# Altium schematic sheet FPGA_PWR.SchDoc
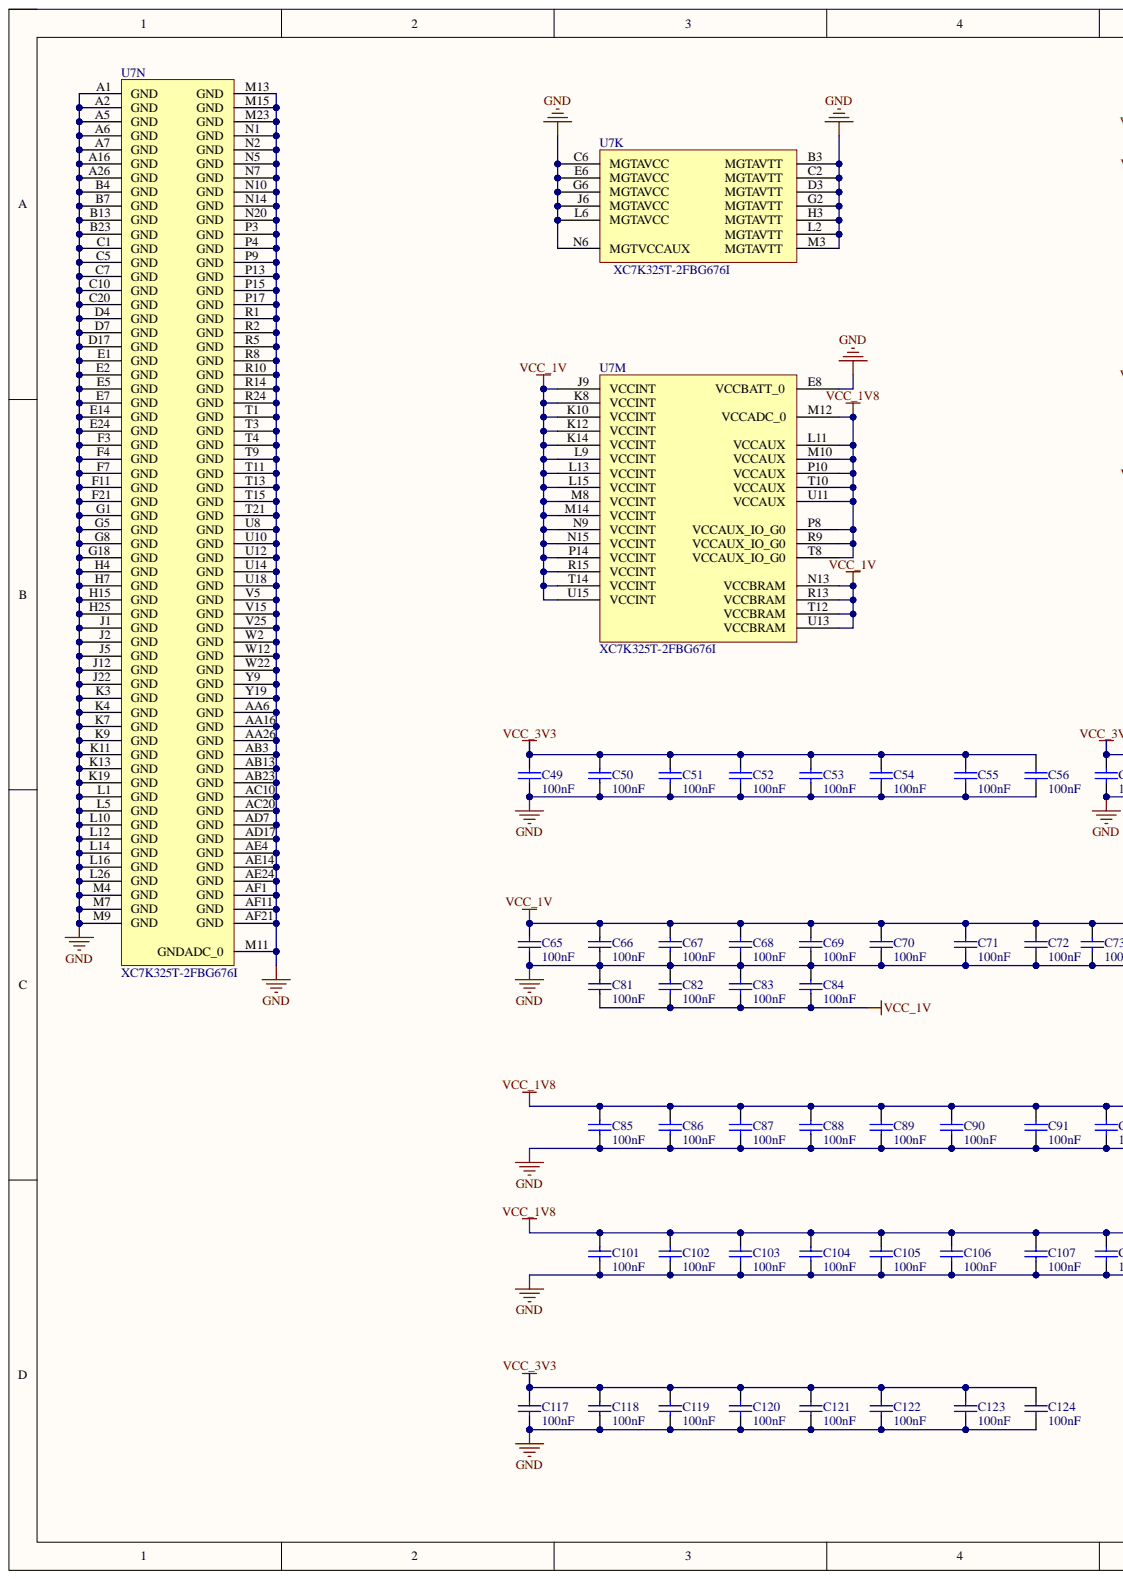
[diagram p0: FPGA_PWR.SchDoc, left]
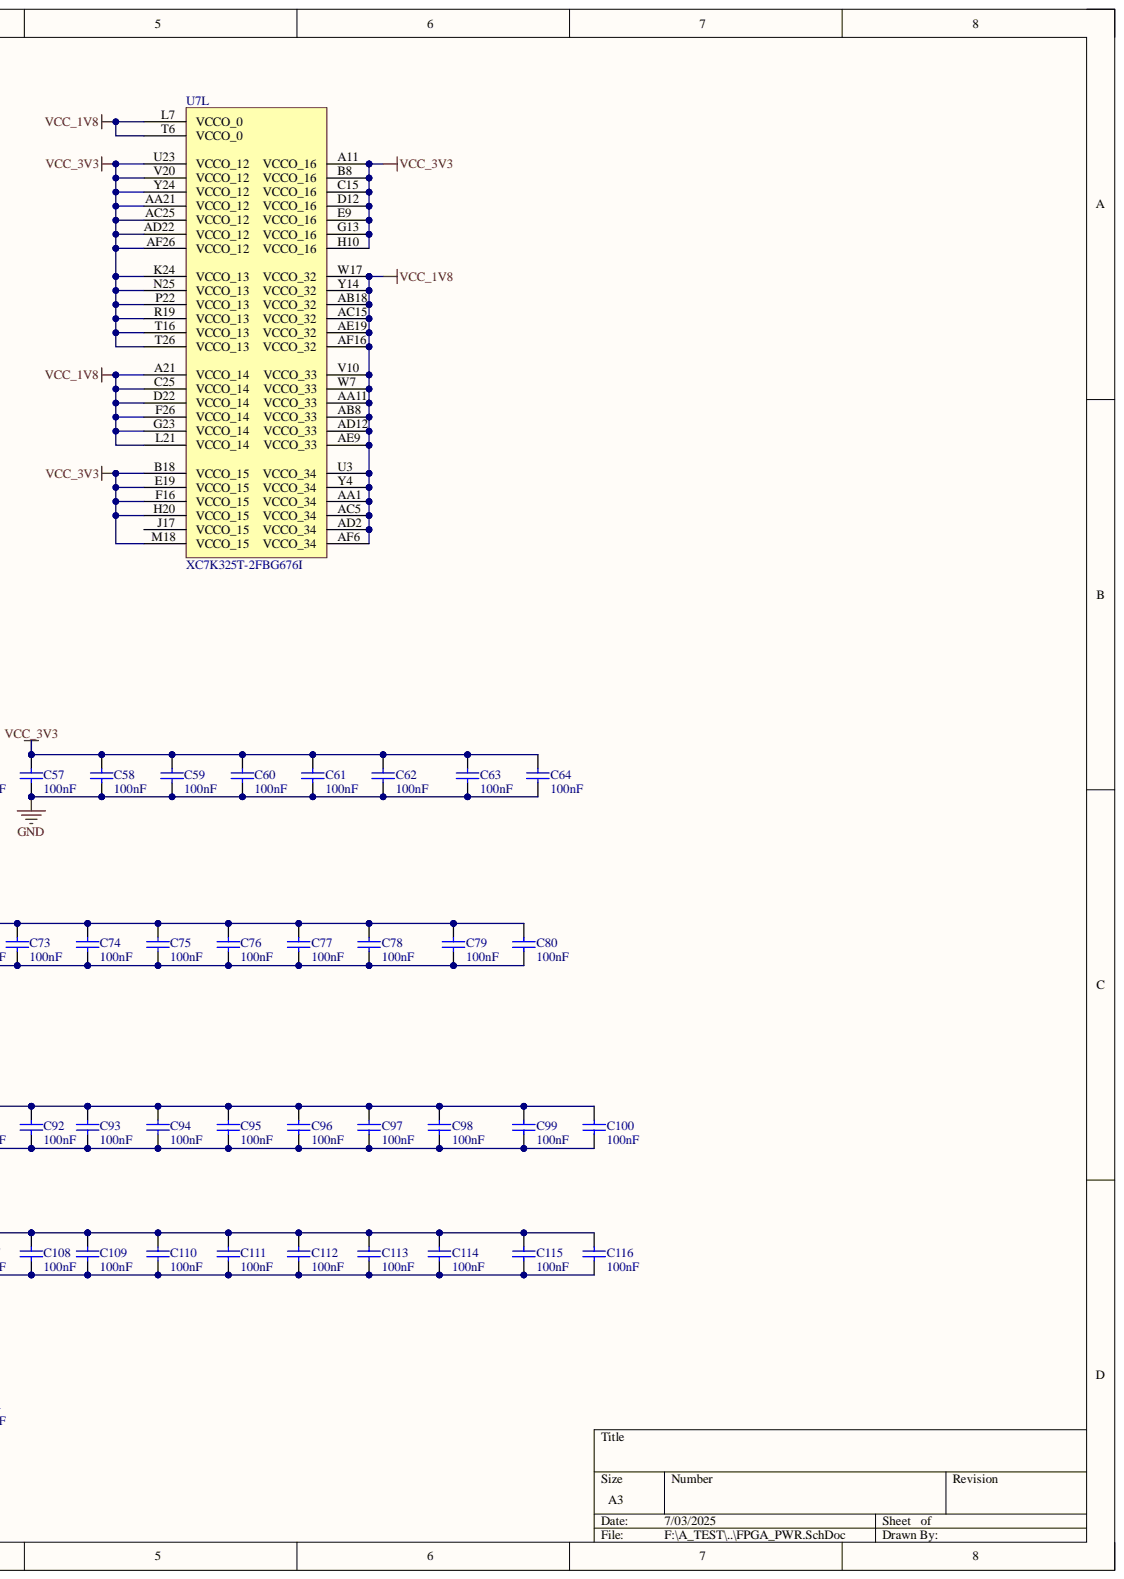
[diagram p1: FPGA_PWR.SchDoc, right]

## Parts
C49  100nF  [C 0402_L]
C50  100nF  [C 0402_L]
C51  100nF  [C 0402_L]
C52  100nF  [C 0402_L]
C53  100nF  [C 0402_L]
C54  100nF  [C 0402_L]
C55  100nF  [C 0402_L]
C56  100nF  [C 0402_L]
C57  100nF  [C 0402_L]
C58  100nF  [C 0402_L]
C59  100nF  [C 0402_L]
C60  100nF  [C 0402_L]
C61  100nF  [C 0402_L]
C62  100nF  [C 0402_L]
C63  100nF  [C 0402_L]
C64  100nF  [C 0402_L]
C65  100nF  [C 0402_L]
C66  100nF  [C 0402_L]
C67  100nF  [C 0402_L]
C68  100nF  [C 0402_L]
C69  100nF  [C 0402_L]
C70  100nF  [C 0402_L]
C71  100nF  [C 0402_L]
C72  100nF  [C 0402_L]
C73  100nF  [C 0402_L]
C74  100nF  [C 0402_L]
C75  100nF  [C 0402_L]
C76  100nF  [C 0402_L]
C77  100nF  [C 0402_L]
C78  100nF  [C 0402_L]
C79  100nF  [C 0402_L]
C80  100nF  [C 0402_L]
C81  100nF  [C 0402_L]
C82  100nF  [C 0402_L]
C83  100nF  [C 0402_L]
C84  100nF  [C 0402_L]
C85  100nF  [C 0402_L]
C86  100nF  [C 0402_L]
C87  100nF  [C 0402_L]
C88  100nF  [C 0402_L]
C89  100nF  [C 0402_L]
C90  100nF  [C 0402_L]
C91  100nF  [C 0402_L]
C92  100nF  [C 0402_L]
C93  100nF  [C 0402_L]
C94  100nF  [C 0402_L]
C95  100nF  [C 0402_L]
C96  100nF  [C 0402_L]
C97  100nF  [C 0402_L]
C98  100nF  [C 0402_L]
C99  100nF  [C 0402_L]
C100  100nF  [C 0402_L]
C101  100nF  [C 0402_L]
C102  100nF  [C 0402_L]
C103  100nF  [C 0402_L]
C104  100nF  [C 0402_L]
C105  100nF  [C 0402_L]
C106  100nF  [C 0402_L]
C107  100nF  [C 0402_L]
C108  100nF  [C 0402_L]
C109  100nF  [C 0402_L]
C110  100nF  [C 0402_L]
C111  100nF  [C 0402_L]
C112  100nF  [C 0402_L]
C113  100nF  [C 0402_L]
C114  100nF  [C 0402_L]
C115  100nF  [C 0402_L]
C116  100nF  [C 0402_L]
C117  100nF  [C 0402_L]
C118  100nF  [C 0402_L]
C119  100nF  [C 0402_L]
C120  100nF  [C 0402_L]
C121  100nF  [C 0402_L]
C122  100nF  [C 0402_L]
C123  100nF  [C 0402_L]
C124  100nF  [C 0402_L]
U7  XC7K325T-2FBG676I  [FFG676]  (parts K,L,M,N)

## Nets
GND: C49.1 C50.1 C51.1 C52.1 C53.1 C54.1 C55.1 C56.1 C57.1 C58.1 C59.1 C60.1 C61.1 C62.1 C63.1 C64.1 C65.1 C66.1 C67.1 C68.1 C69.1 C70.1 C71.1 C72.1 C73.1 C74.1 C75.1 C76.1 C77.1 C78.1 C79.1 C80.1 C81.2 C82.2 C83.2 C84.2 C85.1 C86.1 C87.1 C88.1 C89.1 C90.1 C91.1 C92.1 C93.1 C94.1 C95.1 C96.1 C97.1 C98.1 C99.1 C100.1 C101.1 C102.1 C103.1 C104.1 C105.1 C106.1 C107.1 C108.1 C109.1 C110.1 C111.1 C112.1 C113.1 C114.1 C115.1 C116.1 C117.1 C118.1 C119.1 C120.1 C121.1 C122.1 C123.1 C124.1 U7.A1(GND) U7.A2(GND) U7.A5(GND) U7.A6(GND) U7.A7(GND) U7.A16(GND) U7.A26(GND) U7.AA6(GND) U7.AA16(GND) U7.AA26(GND) U7.AB3(GND) U7.AB13(GND) U7.AB23(GND) U7.AC10(GND) U7.AC20(GND) U7.AD7(GND) U7.AD17(GND) U7.AE4(GND) U7.AE14(GND) U7.AE24(GND) U7.AF1(GND) U7.AF11(GND) U7.AF21(GND) U7.B3(MGTAVTT) U7.B4(GND) U7.B7(GND) U7.B13(GND) U7.B23(GND) U7.C1(GND) U7.C2(MGTAVTT) U7.C5(GND) U7.C6(MGTAVCC) U7.C7(GND) U7.C10(GND) U7.C20(GND) U7.D3(MGTAVTT) U7.D4(GND) U7.D7(GND) U7.D17(GND) U7.E1(GND) U7.E2(GND) U7.E5(GND) U7.E6(MGTAVCC) U7.E7(GND) U7.E8(VCCBATT_0) U7.E14(GND) U7.E24(GND) U7.F3(GND) U7.F4(GND) U7.F7(GND) U7.F11(GND) U7.F21(GND) U7.G1(GND) U7.G2(MGTAVTT) U7.G5(GND) U7.G6(MGTAVCC) U7.G8(GND) U7.G18(GND) U7.H3(MGTAVTT) U7.H4(GND) U7.H7(GND) U7.H15(GND) U7.H25(GND) U7.J1(GND) U7.J2(GND) U7.J5(GND) U7.J6(MGTAVCC) U7.J12(GND) U7.J22(GND) U7.K3(GND) U7.K4(GND) U7.K7(GND) U7.K9(GND) U7.K11(GND) U7.K13(GND) U7.K19(GND) U7.L1(GND) U7.L2(MGTAVTT) U7.L5(GND) U7.L6(MGTAVCC) U7.L10(GND) U7.L12(GND) U7.L14(GND) U7.L16(GND) U7.L26(GND) U7.M3(MGTAVTT) U7.M4(GND) U7.M7(GND) U7.M9(GND) U7.M11(GNDADC_0) U7.M13(GND) U7.M15(GND) U7.M23(GND) U7.N1(GND) U7.N2(GND) U7.N5(GND) U7.N6(MGTVCCAUX) U7.N7(GND) U7.N10(GND) U7.N14(GND) U7.N20(GND) U7.P3(GND) U7.P4(GND) U7.P9(GND) U7.P13(GND) U7.P15(GND) U7.P17(GND) U7.R1(GND) U7.R2(GND) U7.R5(GND) U7.R8(GND) U7.R10(GND) U7.R14(GND) U7.R24(GND) U7.T1(GND) U7.T3(GND) U7.T4(GND) U7.T9(GND) U7.T11(GND) U7.T13(GND) U7.T15(GND) U7.T21(GND) U7.U8(GND) U7.U10(GND) U7.U12(GND) U7.U14(GND) U7.U18(GND) U7.V5(GND) U7.V15(GND) U7.V25(GND) U7.W2(GND) U7.W12(GND) U7.W22(GND) U7.Y9(GND) U7.Y19(GND)
VCC_1V: C65.2 C66.2 C67.2 C68.2 C69.2 C70.2 C71.2 C72.2 C73.2 C74.2 C75.2 C76.2 C77.2 C78.2 C79.2 C80.2 C81.1 C82.1 C83.1 C84.1 U7.J9(VCCINT) U7.K8(VCCINT) U7.K10(VCCINT) U7.K12(VCCINT) U7.K14(VCCINT) U7.L9(VCCINT) U7.L13(VCCINT) U7.L15(VCCINT) U7.M8(VCCINT) U7.M14(VCCINT) U7.N9(VCCINT) U7.N13(VCCBRAM) U7.N15(VCCINT) U7.P14(VCCINT) U7.R13(VCCBRAM) U7.R15(VCCINT) U7.T12(VCCBRAM) U7.T14(VCCINT) U7.U13(VCCBRAM) U7.U15(VCCINT)
VCC_1V8: C85.2 C86.2 C87.2 C88.2 C89.2 C90.2 C91.2 C92.2 C93.2 C94.2 C95.2 C96.2 C97.2 C98.2 C99.2 C100.2 C101.2 C102.2 C103.2 C104.2 C105.2 C106.2 C107.2 C108.2 C109.2 C110.2 C111.2 C112.2 C113.2 C114.2 C115.2 C116.2 U7.A21(VCCO_14) U7.AA1(VCCO_34) U7.AA11(VCCO_33) U7.AB8(VCCO_33) U7.AB18(VCCO_32) U7.AC5(VCCO_34) U7.AC15(VCCO_32) U7.AD2(VCCO_34) U7.AD12(VCCO_33) U7.AE9(VCCO_33) U7.AE19(VCCO_32) U7.AF6(VCCO_34) U7.AF16(VCCO_32) U7.C25(VCCO_14) U7.D22(VCCO_14) U7.F26(VCCO_14) U7.G23(VCCO_14) U7.L7(VCCO_0) U7.L11(VCCAUX) U7.L21(VCCO_14) U7.M10(VCCAUX) U7.M12(VCCADC_0) U7.P8(VCCAUX_IO_G0) U7.P10(VCCAUX) U7.R9(VCCAUX_IO_G0) U7.T6(VCCO_0) U7.T8(VCCAUX_IO_G0) U7.T10(VCCAUX) U7.U3(VCCO_34) U7.U11(VCCAUX) U7.V10(VCCO_33) U7.W7(VCCO_33) U7.W17(VCCO_32) U7.Y4(VCCO_34) U7.Y14(VCCO_32)
VCC_3V3: C49.2 C50.2 C51.2 C52.2 C53.2 C54.2 C55.2 C56.2 C57.2 C58.2 C59.2 C60.2 C61.2 C62.2 C63.2 C64.2 C117.2 C118.2 C119.2 C120.2 C121.2 C122.2 C123.2 C124.2 U7.A11(VCCO_16) U7.AA21(VCCO_12) U7.AC25(VCCO_12) U7.AD22(VCCO_12) U7.AF26(VCCO_12) U7.B8(VCCO_16) U7.B18(VCCO_15) U7.C15(VCCO_16) U7.D12(VCCO_16) U7.E9(VCCO_16) U7.E19(VCCO_15) U7.F16(VCCO_15) U7.G13(VCCO_16) U7.H10(VCCO_16) U7.H20(VCCO_15) U7.K24(VCCO_13) U7.M18(VCCO_15) U7.N25(VCCO_13) U7.P22(VCCO_13) U7.R19(VCCO_13) U7.T16(VCCO_13) U7.T26(VCCO_13) U7.U23(VCCO_12) U7.V20(VCCO_12) U7.Y24(VCCO_12)

Not connected: U7 J17(VCCO_15)

## Library data of the parts
C49-C124 = Cap: 贴片电容; Component Kind: Standard; Library Name: A_Schlib.SchLib; value: 100nF
U7 = XC7K325T-2FBG676I: Kintex-7 FPGA, 500 User I/Os, 8 GTX, 676-Ball BGA, Speed Grade 2, Industrial Grade, Pb-Free; Manufacturer: Xilinx; GTX: 8; SelectIO HP: 150; SelectIO HR: 250; Speed Grade: -2; Code_JEDEC: MS-034; Component Kind: Standard; ComponentLink1Description: Datasheet; ComponentLink1URL: http://www.xilinx.com/support/documentation/data_sheets/ds180_7Series_Overview.pdf; ComponentLink2Description: Package Specification; ComponentLink2URL: http://www.xilinx.com/support/documentation/user_guides/ug475_7Series_Pkg_Pinout.pdf; DatasheetVersion: v1.12; Generic Part Number: XC7K325T; Library Name: Xilinx Kintex-7.IntLib; Mounting Technology: Surface Mount; PackageDescription: Flip-Chip Lidless BGA, Body 27 x 27 mm, 1.0 mm Pitch; PackageReference: FBG676; PackageVersion: Rev 1.8; PartNumber: XC7K325T-2FBG676I; RoHS: Pb-Free
